# Altium schematic sheet CAN 2 Ethernet-Rev2.SchDoc("CAN 2 Ethernet-Rev2")
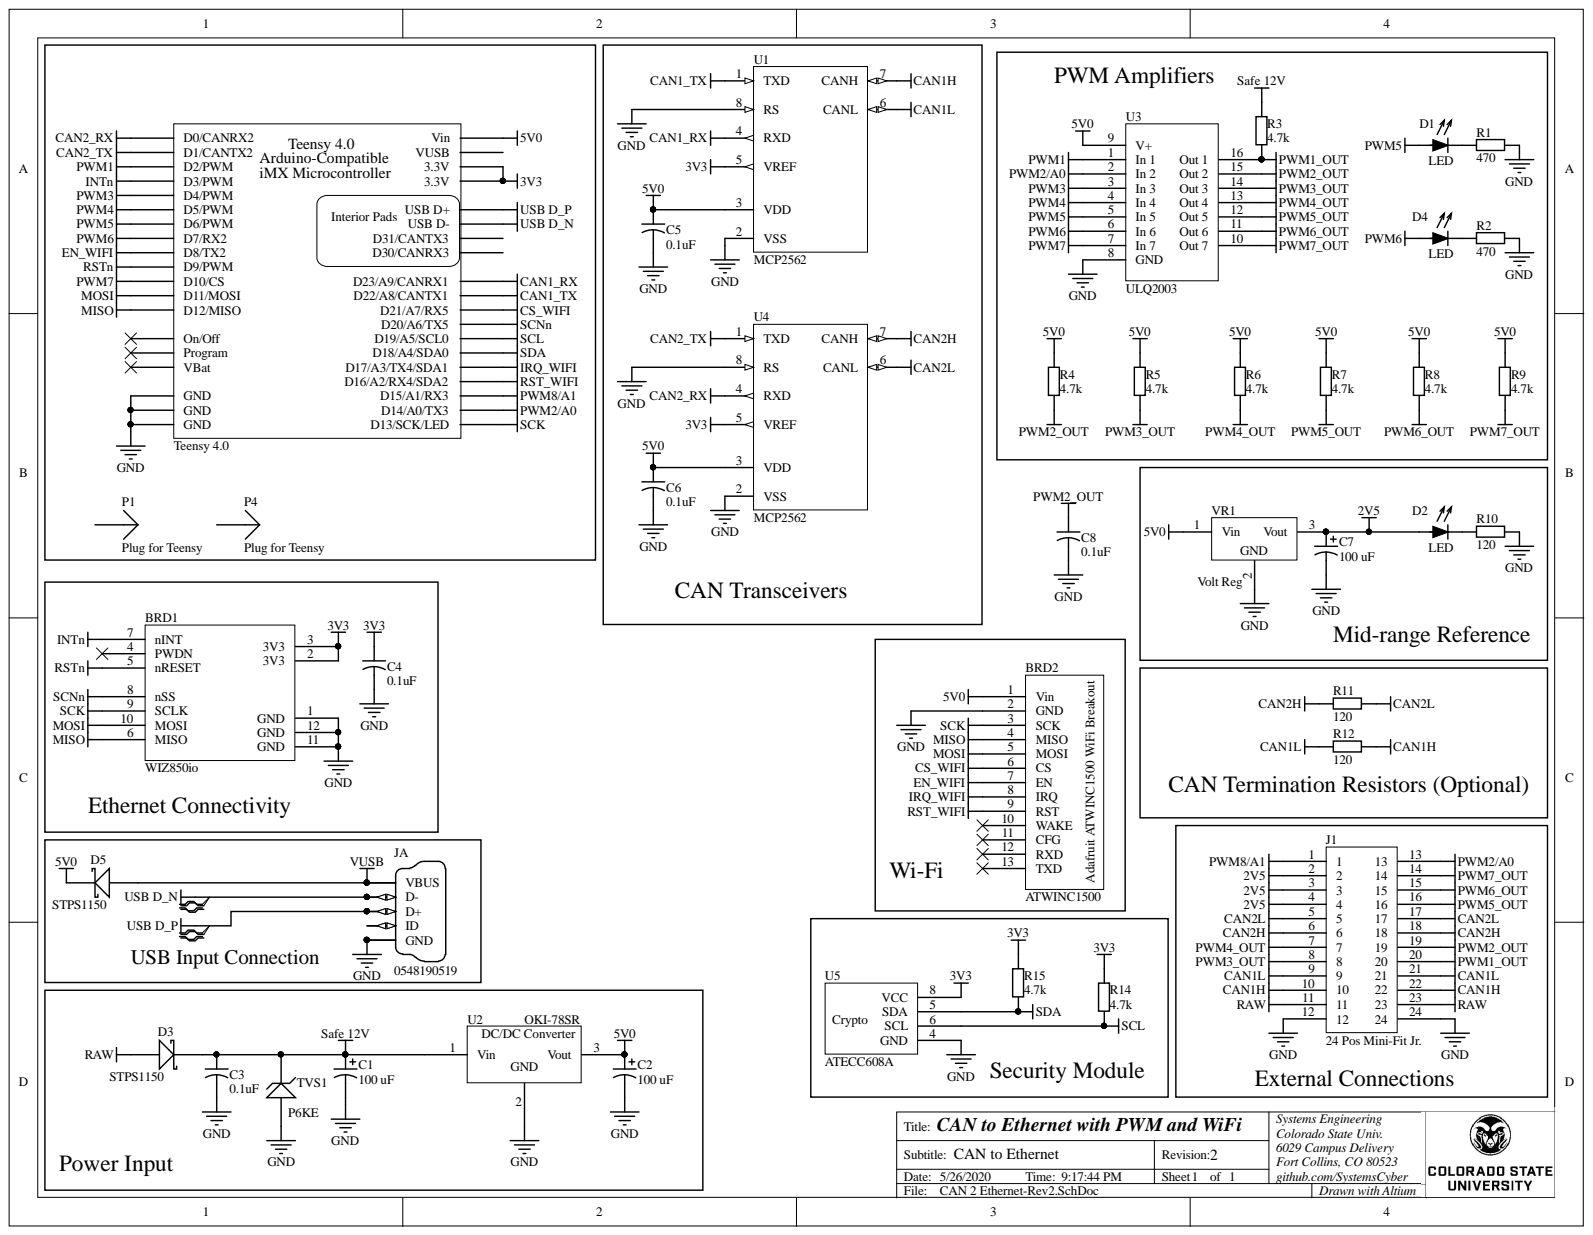
[diagram p0: CAN 2 Ethernet-Rev2.SchDoc]

## Parts
BRD1  WIZ850io  [Wiz820]
BRD2  ATWINC1500  Manufacturer: Adafruit  [Adafruit ATWINC1500]
C1  100 uF  [Elec. Cap 5 x 10mm]
C2  100 uF  [Elec. Cap 5 x 10mm]
C3  0.1uF  [CAPR5-4X5]
C4  0.1uF  [CAPR5-4X5]
C5  0.1uF  [CAPR5-4X5]
C6  0.1uF  [CAPR5-4X5]
C7  100 uF  [Elec. Cap 5 x 10mm]
C8  0.1uF  [CAPR5-4X5]
D1  LED  [LED - 5mm Through Hole]
D2  LED  [LED - 5mm Through Hole]
D3  STPS1150  [DO-204AL]
D4  LED  [LED - 5mm Through Hole]
D5  STPS1150  [DO-204AL]
J  0548190519  [MOLEX_0548190519]  (part A)
J1  24 Pos Mini-Fit Jr.  [24 pos Mini-Fit Jr. R/A Header]
P1  Plug for Teensy
P4  Plug for Teensy
R1  470  [AXIAL-0.4]
R2  470  [AXIAL-0.4]
R3  4.7k  [AXIAL-0.4]
R4  4.7k  [AXIAL-0.4]
R5  4.7k  [AXIAL-0.4]
R6  4.7k  [AXIAL-0.4]
R7  4.7k  [AXIAL-0.4]
R8  4.7k  [AXIAL-0.4]
R9  4.7k  [AXIAL-0.4]
R10  120  [AXIAL-0.4]
R11  120  [AXIAL-0.4]
R12  120  [AXIAL-0.4]
R14  4.7k  [AXIAL-0.4]
R15  4.7k  [AXIAL-0.4]
Teensy_4.0  Teensy 4.0  [Teensy 4.0]
TVS1  P6KE  [DIODE-0.7]
U1  MCP2562  [PDIP300-P8]
U2  OKI-78SR  [OKI-78SR]
U3  ULQ2003  [DIP16]
U4  MCP2562  [PDIP300-P8]
U5  ATECC608A  [SOIC-8]
VR1  Volt Reg  [TO-220-AB]

## Nets
2V5: C7.1 D2.1 J1.2 J1.3 J1.4 VR1.3
3V3: BRD1.2(3V3) BRD1.3(3V3) C4.1 R14.1 R15.1 Teensy_4.0.3V3a(3.3V) Teensy_4.0.3V3b(3.3V) U1.5(VREF) U4.5(VREF) U5.8(VCC)
5V0: BRD2.1(Vin) C2.1 C5.1 C6.1 D5.2 R4.1 R5.1 R6.1 R7.1 R8.1 R9.1 Teensy_4.0.Vin U1.3(VDD) U2.3 U3.9(V+) U4.3(VDD) VR1.1
CAN1_RX: Teensy_4.0.23(D23/A9/CANRX1) U1.4(RXD)
CAN1_TX: Teensy_4.0.22(D22/A8/CANTX1) U1.1(TXD)
CAN1H: J1.10 J1.22 R12.2 U1.7(CANH)
CAN1L: J1.9 J1.21 R12.1 U1.6(CANL)
CAN2_RX: Teensy_4.0.0(D0/CANRX2) U4.4(RXD)
CAN2_TX: Teensy_4.0.1(D1/CANTX2) U4.1(TXD)
CAN2H: J1.6 J1.18 R11.2 U4.7(CANH)
CAN2L: J1.5 J1.17 R11.1 U4.6(CANL)
CS_WIFI: BRD2.6(CS) Teensy_4.0.21(D21/A7/RX5)
EN_WIFI: BRD2.7(EN) Teensy_4.0.8(D8/TX2)
GND: BRD1.1(GND) BRD1.11(GND) BRD1.12(GND) BRD2.2(GND) C1.2 C2.2 C3.2 C4.2 C5.2 C6.2 C7.2 C8.2 J.5 J.10(GND) J1.12 J1.24 R1.2 R2.2 R10.2 Teensy_4.0.G1(GND) Teensy_4.0.G2(GND) Teensy_4.0.G3(GND) TVS1.1 U1.2(VSS) U1.8(RS) U2.2 U3.8(GND) U4.2(VSS) U4.8(RS) U5.4(GND) VR1.2
INTn: BRD1.7(nINT) Teensy_4.0.3(D3/PWM)
IRQ_WIFI: BRD2.8(IRQ) Teensy_4.0.17(D17/A3/TX4/SDA1)
MISO: BRD1.6(MISO) BRD2.4(MISO) Teensy_4.0.12(D12/MISO)
MOSI: BRD1.10(MOSI) BRD2.5(MOSI) Teensy_4.0.11(D11/MOSI)
PWM1: Teensy_4.0.2(D2/PWM) U3.1(In_1)
PWM1_OUT: J1.20 R3.2 U3.16(Out_1)
PWM2/A0: J1.13 Teensy_4.0.14(D14/A0/TX3) U3.2(In_2)
PWM2_OUT: C8.1 J1.19 R4.2 U3.15(Out_2)
PWM3: Teensy_4.0.4(D4/PWM) U3.3(In_3)
PWM3_OUT: J1.8 R5.2 U3.14(Out_3)
PWM4: Teensy_4.0.5(D5/PWM) U3.4(In_4)
PWM4_OUT: J1.7 R6.2 U3.13(Out_4)
PWM5: D1.1 Teensy_4.0.6(D6/PWM) U3.5(In_5)
PWM5_OUT: J1.16 R7.2 U3.12(Out_5)
PWM6: D4.1 Teensy_4.0.7(D7/RX2) U3.6(In_6)
PWM6_OUT: J1.15 R8.2 U3.11(Out_6)
PWM7: Teensy_4.0.10(D10/CS) U3.7(In_7)
PWM7_OUT: J1.14 R9.2 U3.10(Out_7)
PWM8/A1: J1.1 Teensy_4.0.15(D15/A1/RX3)
RAW: D3.1 J1.11 J1.23
RST_WIFI: BRD2.9(RST) Teensy_4.0.16(D16/A2/RX4/SDA2)
RSTn: BRD1.5(nRESET) Teensy_4.0.9(D9/PWM)
Safe 12V: C1.1 C3.1 D3.2 R3.1 TVS1.2 U2.1
SCK: BRD1.9(SCLK) BRD2.3(SCK) Teensy_4.0.13(D13/SCK/LED)
SCL: R14.2 Teensy_4.0.19(D19/A5/SCL0) U5.6(SCL)
SCNn: BRD1.8(nSS) Teensy_4.0.20(D20/A6/TX5)
SDA: R15.2 Teensy_4.0.18(D18/A4/SDA0) U5.5(SDA)
USB D_N: J.2(D-) J.7 Teensy_4.0.D-
USB D_P: J.3(D+) J.8 Teensy_4.0.D+(USB_D+)
VUSB: D5.1 J.1(VBUS) J.6
NetD1_2: D1.2 R1.1
NetD2_2: D2.2 R10.1
NetD4_2: D4.2 R2.1
NetJ_4: J.4(ID) J.9

Not connected: BRD1 4(PWDN); BRD2 10(WAKE) 11(CFG) 12(RXD) 13(TXD); P1 1; P4 1; Teensy_4.0 CRX3(D30/CANRX3) CTX3(D31/CANTX3) On(On/Off) P(Program) VBat VUSB

## Library data of the parts
BRD1 = WIZ820io: Ethernet Modules W5200 & MAG JACK ioPLATFORM MODULE; Manufacturer: WIZNET; Alternative: None; Supplier Part Number 1: 950-WIZ850IO; Supplier 1: Mouser; PartNumber: WIZ850io; Library Name: TU Custom Parts Schematics.SchLib; Component Kind: Standard
BRD2 = Adafruit ATWINC1500: Adafruit ATWINC1500 WiFi Breakout, PRODUCT ID: 2999; PartNumber: 3060; Alternative: None; Supplier Part Number 1: 485-3060; Supplier 1: Mouser; Library Name: TU Custom Parts Schematics.SchLib; Component Kind: Standard
C1 C2 C7 = Cap Pol1: 100µF 160V Aluminum Electrolytic Capacitors Radial, Can  8000 Hrs @ 105°C; PartNumber: UPW2C101MHD; Manufacturer: Nichicon; Alternative: Acceptable; Supplier Part Number 1: 493-1998-ND; Supplier 1: Digi-Key; Simulation: CAP; Signal Integrity: Cap Pol; Library Name: Miscellaneous Devices.IntLib; Component Kind: Standard
C3-C6 C8 = Cap2: Capacitor; PartNumber: C330C104K2R5TA; Manufacturer: KEMET; Alternative: Acceptable; Supplier Part Number 1: 399-4387-ND; Supplier 1: Digi-Key; Simulation: CAP; Signal Integrity: Cap; Library Name: Miscellaneous Devices.IntLib; Component Kind: Standard
D1 = LED: Light Emmitting Diode; PartNumber: INL-5AR30; Manufacturer: Inolux; Alternative: Acceptable; Supplier Part Number 1: 743-INL-5AR30; Supplier 1: Mouser; Library Name: TU Custom Parts Schematics.SchLib; Component Kind: Standard
D2 D4 = LED: Light Emmitting Diode; PartNumber: LTL2R3KGD-EM; Manufacturer: Lite-On; Alternative: Acceptable; Supplier Part Number 1: 859-LTL2R3KGD-EM; Supplier 1: Mouser; Library Name: TU Custom Parts Schematics.SchLib; Component Kind: Standard
D3 D5 = Diode 11DQ03: Diode Schottky 150V 1A Through Hole DO-41; Manufacturer: STMicroelectronics; Alternative: Acceptable; Supplier Part Number 1: 497-2447-1-ND; Supplier 1: Digi-Key; Simulation: 11DQ03; PartNumber: STPS1150; Library Name: Miscellaneous Devices.IntLib; Component Kind: Standard
J = 0548190519: USB - mini B USB 2.0 OTG Receptacle Connector 5 Position Through Hole, Right Angle; Manufacturer: Molex; Supplier Part Number 1: WM17115-ND; Supplier 1: Digi-Key; PartNumber: 0548190519; Library Name: 0548190519.IntLib; Component Kind: Standard
J1 = 24 Pos Header: Manufacturer: Molex; Supplier 1: Digi-Key; PartNumber: 39-30-1240; Library Name: Molex Mini-Fit Jr.IntLib; Component Kind: Standard
P1 P4 = Plug: 24 Position Header Connector 0.100" (2.54mm) Through Hole Tin; PartNumber: Sullins Connector Solutions; Alternative: Acceptable; Supplier Part Number 1: S7022-ND; Supplier 1: Digi-Key; Signal Integrity: Connector; Manufacturer: Sullins Connector Solutions; Library Name: Miscellaneous Connectors.IntLib; Component Kind: Standard
R1 R2 R10-R12 = Res2: Resistor; PartNumber: 470QBK-ND; Manufacturer: Yageo; Alternative: Acceptable; Supplier Part Number 1: 470QBK-ND; Supplier 1: Digi-Key; Simulation: RESISTOR; Signal Integrity: Res; Library Name: Miscellaneous Devices.IntLib; Component Kind: Standard
R3-R9 R14 R15 = Res2: Resistor; Value: 1K; Simulation: RESISTOR; Signal Integrity: Res; Published: 8-Jun-2000; PackageReference: AXIAL-0.4; PackageDescription: Axial Device, Thru-Hole; 2 Leads; 0.4 in Pin Spacing; Library Name: Miscellaneous Devices.IntLib; LatestRevisionNote: Re-released for DXP Platform.; LatestRevisionDate: 17-Jul-2002; Component Kind: Standard; Publisher: Altium Limited
Teensy_4.0 = Teensy_4.0: 32 Bit Arduino-Compatible iMX Microcontroller; Manufacturer: PRJC; Supplier Part Number 1: 1568-DEV-15583-ND; Supplier 1: Digi-Key; PartNumber: Teensy 4.0; Library Name: Teensy.IntLib; Component Kind: Standard
TVS1 = D Zener: TVS DIODE 25.6V 41.4V DO204AC; PartNumber: P6KE30CA; Manufacturer: Littelfuse Inc.; Alternative: Acceptable; Supplier Part Number 1: P6KE30CALFCT-ND; Supplier 1: Digi-Key; Simulation: ZENER; Signal Integrity: D Zener; Library Name: Miscellaneous Devices.IntLib; Component Kind: Standard
U1 U4 = MCP2551-E/P: 1/1 Transceiver Half CANbus 8-PDIP; PartNumber: MCP2562-E/P; Manufacturer: Microchip; Alternative: MCP2562FD-E/P; Supplier Part Number 1: MCP2562-E/P-ND; Supplier 1: Digi-Key; Library Name: Microchip Interface.IntLib; Component Kind: Standard
U2 = 7805: Linear Regulator Replacement DC DC Converter 1 Output 5V 1.5A 7V - 36V Input; PartNumber: OKI-78SR-5/1.5-W36-C; Manufacturer: Murata Power Solutions; Supplier Part Number 1: 811-2692-ND; Supplier 1: Digi-Key; Library Name: SSS Integrated Library.IntLib; Component Kind: Standard
U3 = ULQ2003: High voltage, high current Darlington arrays each containing seven open collector Darlington pairswith common emitters.; Library Name: Custom Parts.IntLib; Component Kind: Standard
U5 = ATECC508A: Authentication Chip IC Networking and Communications 8-SOIC; PartNumber: ATECC608A-SSHCZ-T; Manufacturer: Microchip Technology; Supplier Part Number 1: ATECC608A-SSHCZ-TCT-ND; Supplier 1: Digi-Key; Library Name: TU Custom Parts Schematics.SchLib; Component Kind: Standard
VR1 = Volt Reg: Voltage Regulator; Library Name: Miscellaneous Devices.IntLib; Component Kind: Standard
